# Altium schematic sheet Signalight_1.SchDoc
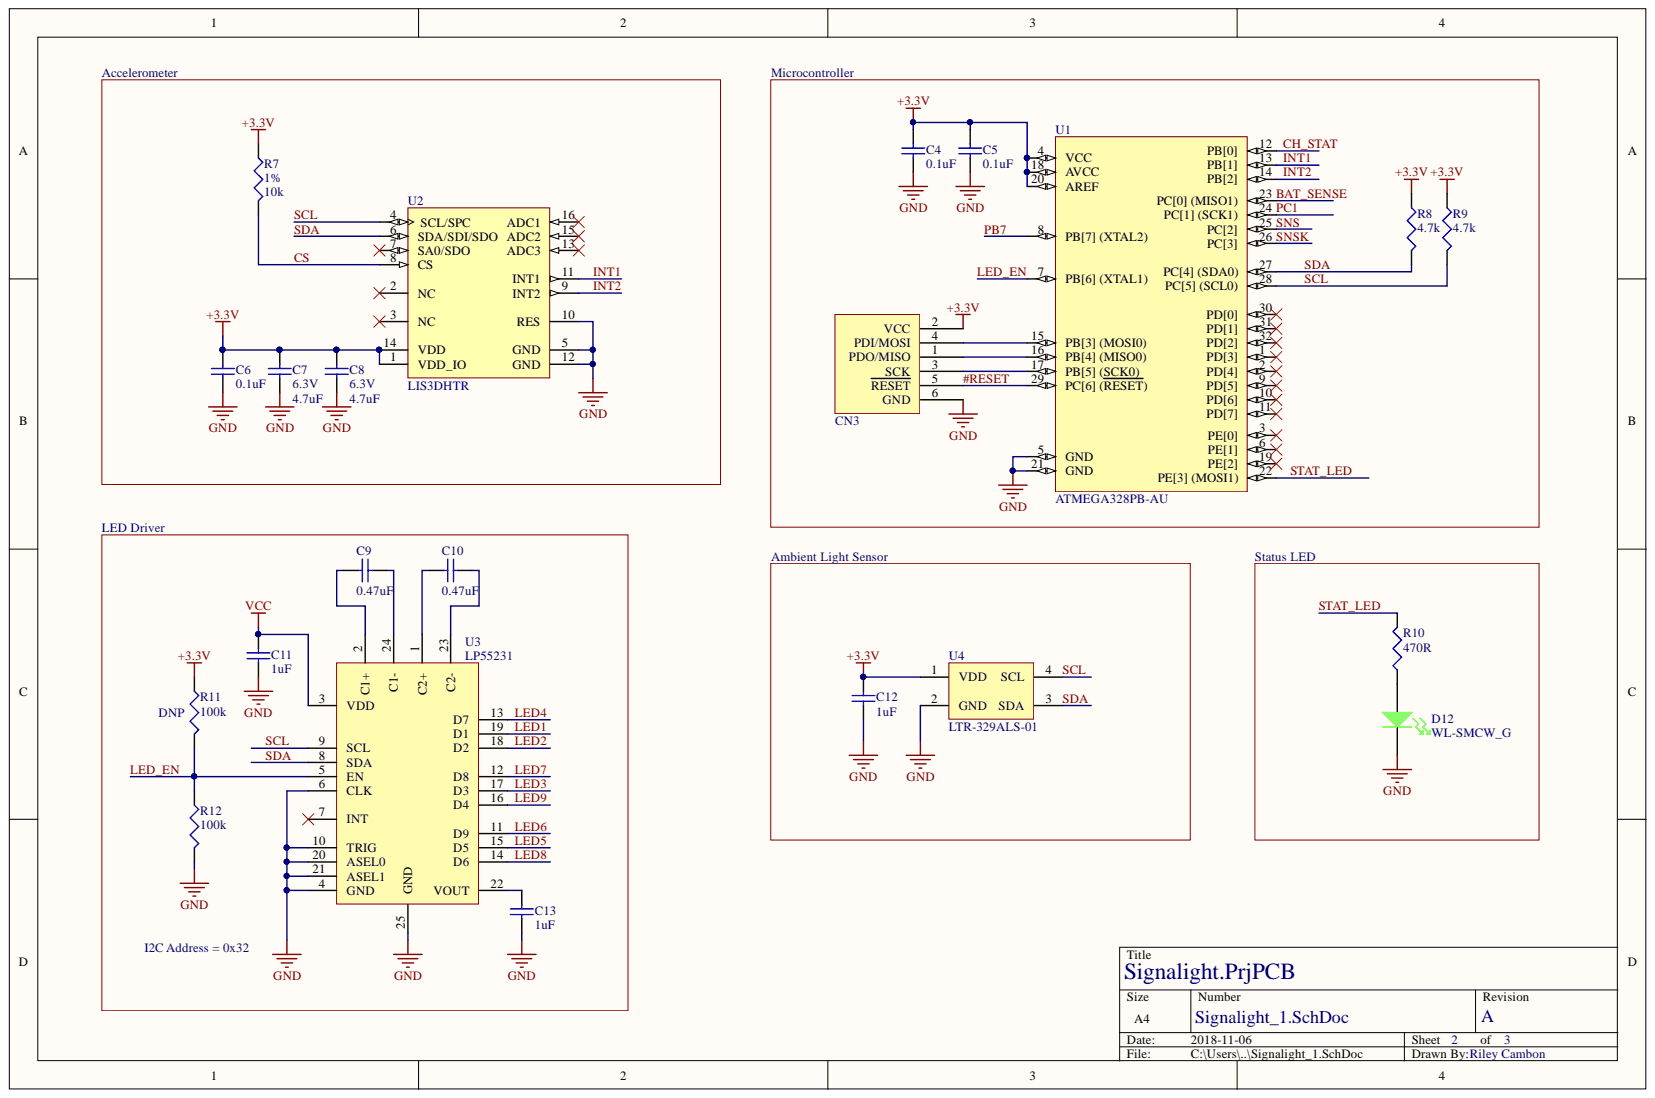
[diagram p0: Signalight_1.SchDoc]

## Parts
C4  value 0.1uF  [CAP CER 0.1UF 16V X7R 0603]  (hidden comment: CAP CER 0.1uF 10% 10V X7R 0603_1)
C5  value 0.1uF  [CAP CER 0.1UF 16V X7R 0603]  (hidden comment: CAP CER 0.1uF 10% 10V X7R 0603_1)
C6  value 0.1uF  [CAP CER 0.1UF 16V X7R 0603]  (hidden comment: CAP CER 0.1uF 10% 10V X7R 0603_1)
C7  value 4.7uF  Voltage Rating: 6.3V  [TMK107B7105KA-T]  (hidden comment: CAP CER 4.7uF 10% 25V X7R 0603)
C8  value 4.7uF  Voltage Rating: 6.3V  [TMK107B7105KA-T]  (hidden comment: CAP CER 4.7uF 10% 25V X7R 0603)
C9  value 0.47uF  [CAP CER 0.1UF 16V X7R 0603]  (hidden comment: CAP CER 0.47uF 10% 10V X7R 0603)
C10  value 0.47uF  [CAP CER 0.1UF 16V X7R 0603]  (hidden comment: CAP CER 0.47uF 10% 10V X7R 0603)
C11  value 1uF  [TMK107B7105KA-T]  (hidden comment: CAP CER 1uF 10% 25V X7R 0603)
C12  value 1uF  [TMK107B7105KA-T]  (hidden comment: CAP CER 1uF 10% 25V X7R 0603)
C13  value 1uF  [TMK107B7105KA-T]  (hidden comment: CAP CER 1uF 10% 25V X7R 0603)
CN3  SWD  [SWD_AVR]  (value not drawn)
D12  Manufacturer Part Number: WL-SMCW_G  [WL-SMCW]  (hidden comment: WL-SMCW_GREEN)
R7  value 10k  Tolerance: 1%  [RES_0603_YAGEO]  (hidden comment: RES 10K 1% 1/10W 0603)
R8  value 4.7k  [RES_0603_YAGEO]  (hidden comment: RES 4.7K 1% 1/10W 0603)
R9  value 4.7k  [RES_0603_YAGEO]  (hidden comment: RES 4.7K 1% 1/10W 0603)
R10  value 470R  [RES_0603_YAGEO]  (hidden comment: RES 470R 1% 1/10W 0603)
R11  value 100k  [RES_0603_YAGEO]  (hidden comment: RES 100K 1% 1/10W 0603)
R12  value 100k  [RES_0603_YAGEO]  (hidden comment: RES 100K 1% 1/10W 0603)
U1  ATMEGA328PB-AU  [ATmega328PB]
U2  LIS3DHTR  [STM-LGA-16_N]
U3  LP55231  [LP55231]
U4  LTR-329ALS-01  [LTR-329ALS-01]

## Nets
+3.3V: C4.1 C5.1 C6.2 C7.2 C8.2 C12.2 CN3.2(VCC) R7.1 R8.1 R9.1 R11.1 U1.4(VCC) U1.18(AVCC) U1.20(AREF) U2.1(VDD_IO) U2.14(VDD) U4.1(VDD)
GND: C4.2 C5.2 C6.1 C7.1 C8.1 C11.1 C12.1 C13.1 CN3.6(GND) D12.2 R12.2 U1.5(GND) U1.21(GND) U2.5(GND) U2.10(RES) U2.12(GND) U3.4(GND) U3.6(CLK) U3.10(TRIG) U3.20(ASEL0) U3.21(ASEL1) U3.25(GND) U4.2(GND)
VCC: C11.2 U3.3(VDD)
#RESET: CN3.5(RESET) U1.29(PC[6]_(RESET))
BAT_SENSE: U1.23(PC[0]_(MISO1))
CH_STAT: U1.12(PB[0])
CS: R7.2 U2.8(CS)
INT1: U1.13(PB[1]) U2.11(INT1)
INT2: U1.14(PB[2]) U2.9(INT2)
LED1: U3.19(D1)
LED2: U3.18(D2)
LED3: U3.17(D3)
LED4: U3.13(D7)
LED5: U3.15(D5)
LED6: U3.11(D9)
LED7: U3.12(D8)
LED8: U3.14(D6)
LED9: U3.16(D4)
LED_EN: R11.2 R12.1 U1.7(PB[6]_(XTAL1)) U3.5(EN)
PB7: U1.8(PB[7]_(XTAL2))
PC1: U1.24(PC[1]_(SCK1))
SCL: R9.2 U1.28(PC[5]_(SCL0)) U2.4(SCL/SPC) U3.9(SCL) U4.4(SCL)
SDA: R8.2 U1.27(PC[4]_(SDA0)) U2.6(SDA/SDI/SDO) U3.8(SDA) U4.3(SDA)
SNS: U1.25(PC[2])
SNSK: U1.26(PC[3])
STAT_LED: R10.2 U1.22(PE[3]_(MOSI1))
NetC9_1: C9.1 U3.2(C1+)
NetC9_2: C9.2 U3.24(C1-)
NetC10_1: C10.1 U3.1(C2+)
NetC10_2: C10.2 U3.23(C2-)
NetC13_2: C13.2 U3.22(VOUT)
NetCN3_1: CN3.1(PDO/MISO) U1.16(PB[4]_(MISO0))
NetCN3_3: CN3.3(SCK) U1.17(PB[5]_(SCK0))
NetCN3_4: CN3.4(PDI/MOSI) U1.15(PB[3]_(MOSI0))
NetD12_1: D12.1 R10.1

Not connected: U1 1(PD[3]) 2(PD[4]) 3(PE[0]) 6(PE[1]) 9(PD[5]) 10(PD[6]) 11(PD[7]) 19(PE[2]) 30(PD[0]) 31(PD[1]) 32(PD[2]); U2 2(NC) 3(NC) 7(SA0/SDO) 13(ADC3) 15(ADC2) 16(ADC1); U3 7(INT)

## Library data of the parts
C4-C6 = CAP CER 0.1uF 10% 10V X7R 0603_1: CAP CER 0.1uF 10% 16V X7R 0603; Component Kind: Standard; ComponentLink1Description: Datasheet; ComponentLink1URL: http://search.murata.co.jp/Ceramy/image/img/A01X/G101/ENG/GRM188R71C104KA01-01.pdf; ComponentLink2Description: Digikey; ComponentLink2URL: https://www.digikey.ca/product-detail/en/murata-electronics-north-america/GRM188R71C104KA01D/490-1532-1-ND/587771; Library Name: Capacitors.IntLib; Package: 0603; Temp Coefficient: X7R; Tolerance: 10%; Voltage Rating: 16V
C7 C8 = CAP CER 4.7uF 10% 25V X7R 0603: CAP CER 1uF 10% 25V X7R 0603; Component Kind: Standard; ComponentLink1Description: Datasheet; ComponentLink1URL: http://ds.yuden.co.jp/TYCOMPAS/ut/detail.do?productNo=JMK107BB7475KA-T&fileName=JMK107BB7475KA-T_SS&mode=specSheetDownload; ComponentLink2Description: Digikey; ComponentLink2URL: https://www.digikey.ca/product-detail/en/taiyo-yuden/JMK107BB7475KA-T/587-5870-1-ND/7674990; Library Name: Capacitors.IntLib; Package: 0603; Temp Coefficient: X7R; Tolerance: 10%
C9 C10 = CAP CER 0.47uF 10% 10V X7R 0603: CAP CER 0.47uF 10% 16V X7R 0603; Component Kind: Standard; ComponentLink1Description: Datasheet; ComponentLink1URL: http://search.murata.co.jp/Ceramy/image/img/A01X/G101/ENG/GRM188R71C104KA01-01.pdf; ComponentLink2Description: Digikey; ComponentLink2URL: https://www.digikey.ca/product-detail/en/murata-electronics-north-america/GCM188R71C474KA55D/490-4775-1-ND/1641694; Library Name: Capacitors.IntLib; Package: 0603; Temp Coefficient: X7R; Tolerance: 10%; Voltage Rating: 10V
CN3 = SWD_AVR: SWD programming header; Component Kind: Standard; Library Name: Connectors.IntLib
D12 = WL-SMCW_GREEN: Diode LED Green 20mA 0603; Forward Voltage: 3.2V; Component Kind: Standard; ComponentLink1Description: Datasheet; ComponentLink1URL: http://katalog.we-online.de/led/datasheet/150060GS75000.pdf; ComponentLink2Description: Digikey; ComponentLink2URL: https://www.digikey.com/product-detail/en/wurth-electronics-inc/150060GS75000/732-4971-1-ND/4489896; Library Name: Diodes.IntLib; Package: 0603
R8 R9 = RES 4.7K 1% 1/10W 0603: RES 1.2K 1% 1/10W 0603; Component Kind: Standard; ComponentLink1Description: Datasheet; ComponentLink1URL: http://www.yageo.com/documents/recent/PYu-RC_Group_51_RoHS_L_8.pdf; ComponentLink2Description: Digikey; ComponentLink2URL: https://www.digikey.ca/products/en?keywords=311-4.70KHRCT-ND; Library Name: Resistors.IntLib; Power Rating: 1/10W; Size: 0603; Tolerance: 1%
U1 = ATMEGA328PB-AU: IC MCU 8BIT 32KB FLASH 32TQFP; Component Kind: Standard; ComponentLink1Description: Datasheet; ComponentLink1URL: http://ww1.microchip.com/downloads/en/DeviceDoc/Microchip%20AVR%20microcontroller%20ATmega328PB%20Data%20Sheet%2040001906B.pdf; ComponentLink2Description: Digikey; ComponentLink2URL: https://www.digikey.ca/product-detail/en/microchip-technology/ATMEGA328PB-AU/ATMEGA328PB-AU-ND/5638812; Library Name: ICs.IntLib; Manufacturer Part Number: ATMEGA328PB-AU; Package: 8-SOIC
U2 = CMP-0234-00055-3: MEMS Digital Output Motion Sensor Ultra Low-Power High Performance 3-Axes "nano" Accelerometer, 1.71 to 3.6 V, -40 to 85 degC, 16-pin (LGA-16), Pb-Free, Tape and Reel; Manufacturer: STMicroelectronics; Bandwidth: 5 kHz; Current Consumption in Power-Down mode: 0.5 uA; Interface: I2C, SPI; Component Kind: Standard; ComponentLink1Description: Manufacturer URL; ComponentLink1URL: http://www.st.com/; ComponentLink2Description: Datasheet; ComponentLink2URL: http://www.st.com/st-web-ui/static/active/en/resource/technical/document/datasheet/CD00274221.pdf; ComponentLink3Description: Package Specification; ComponentLink3URL: http://www.st.com/st-web-ui/static/active/en/resource/technical/document/datasheet/CD00274221.pdf; DatasheetVersion: Rev. 1; Library Name: Altium Content Vault; Mounting Technology: Surface Mount; Operating Temperature: -40 to 85 degC; Output Type: Digital; PackageDescription: 16-Pin Land Grid Array, Body 3 x 3 mm, Pitch 0.5 mm; PackageReference: LGA-16; Packing: Tape and Reel; PartNumber: LIS3DHTR; RoHS: TRUE; Wide Supply Voltage: 1.71 to 3.6 V
U3 = LP55231: 9 channel I2C Controlled  RGB LED Driver; Component Kind: Standard; ComponentLink1Description: Datasheet; ComponentLink1URL: http://www.ti.com/lit/ds/symlink/lp55231.pdf; ComponentLink2Description: Digikey; ComponentLink2URL: https://www.digikey.ca/product-detail/en/texas-instruments/LP55231SQX-NOPB/296-40354-1-ND/5178231; Library Name: ICs.IntLib; Manufacturer Part Number: LP55231
U4 = LTR-329ALS-01: Optical Sensor Ambient I²C 4-VDFN; Component Kind: Standard; ComponentLink1Description: Datasheet; ComponentLink1URL: http://optoelectronics.liteon.com/upload/download/DS86-2014-0006/LTR-329ALS-01_DS_V1.pdf; ComponentLink2Description: Digikey; ComponentLink2URL: https://www.digikey.ca/scripts/DkSearch/dksus.dll?Detail&itemSeq=257423556&uq=636589545642676645; Library Name: ICs.IntLib; Manufacturer Part Number: LTR-329ALS-01; Package: 4-VDFN
C11-C13 = CAP CER 1uF 10% 25V X7R 0603: Component Kind: Standard; ComponentLink1Description: Datasheet; ComponentLink1URL: http://ds.yuden.co.jp/TYCOMPAS/ut/detail.do?productNo=TMK107B7105KA-T&fileName=TMK107B7105KA-T_SS&mode=specSheetDownload; ComponentLink2Description: Digikey; ComponentLink2URL: https://www.digikey.ca/products/en?keywords=1276-1942-1-ND; Description: CAP CER 1uF 10% 25V X7R 0603; Library Name: Capacitors.IntLib; Package: 0603; Temp Coefficient: X7R; Tolerance: 10%; Voltage Rating: 25V
R7 = RES 10K 1% 1/10W 0603: Component Kind: Standard; ComponentLink1Description: Datasheet; ComponentLink1URL: http://www.yageo.com/documents/recent/PYu-RC_Group_51_RoHS_L_8.pdf; ComponentLink2Description: Digikey; ComponentLink2URL: https://www.digikey.ca/product-detail/en/yageo/RC0603FR-0710KL/311-10.0KHRCT-ND/729827; Description: RES 10K 1% 1/10W 0603; Library Name: Resistors.IntLib; Power Rating: 1/10W; Size: 0603
R10 = RES 470R 1% 1/10W 0603: Component Kind: Standard; ComponentLink1Description: Datasheet; ComponentLink1URL: http://www.yageo.com/documents/recent/PYu-RC_Group_51_RoHS_L_8.pdf; ComponentLink2Description: Digikey; ComponentLink2URL: https://www.digikey.ca/product-detail/en/yageo/RC0603FR-07470RL/311-470HRCT-ND/730203; Description: RES 470R 1% 1/10W 0603; Library Name: Resistors.IntLib; Power Rating: 1/10W; Size: 0603; Tolerance: 1%
R11 R12 = RES 100K 1% 1/10W 0603: Component Kind: Standard; ComponentLink1Description: Datasheet; ComponentLink1URL: http://www.yageo.com/documents/recent/PYu-RC_Group_51_RoHS_L_8.pdf; ComponentLink2Description: Digikey; ComponentLink2URL: https://www.digikey.ca/product-detail/en/yageo/RC0603FR-07100KL/311-100KHRDKR-ND/732783; Description: RES 100K 1% 1/10W 0603; Library Name: Resistors.IntLib; Power Rating: 1/10W; Size: 0603; Tolerance: 1%
